# Altium schematic sheet 08_FPGA_C.SchDoc
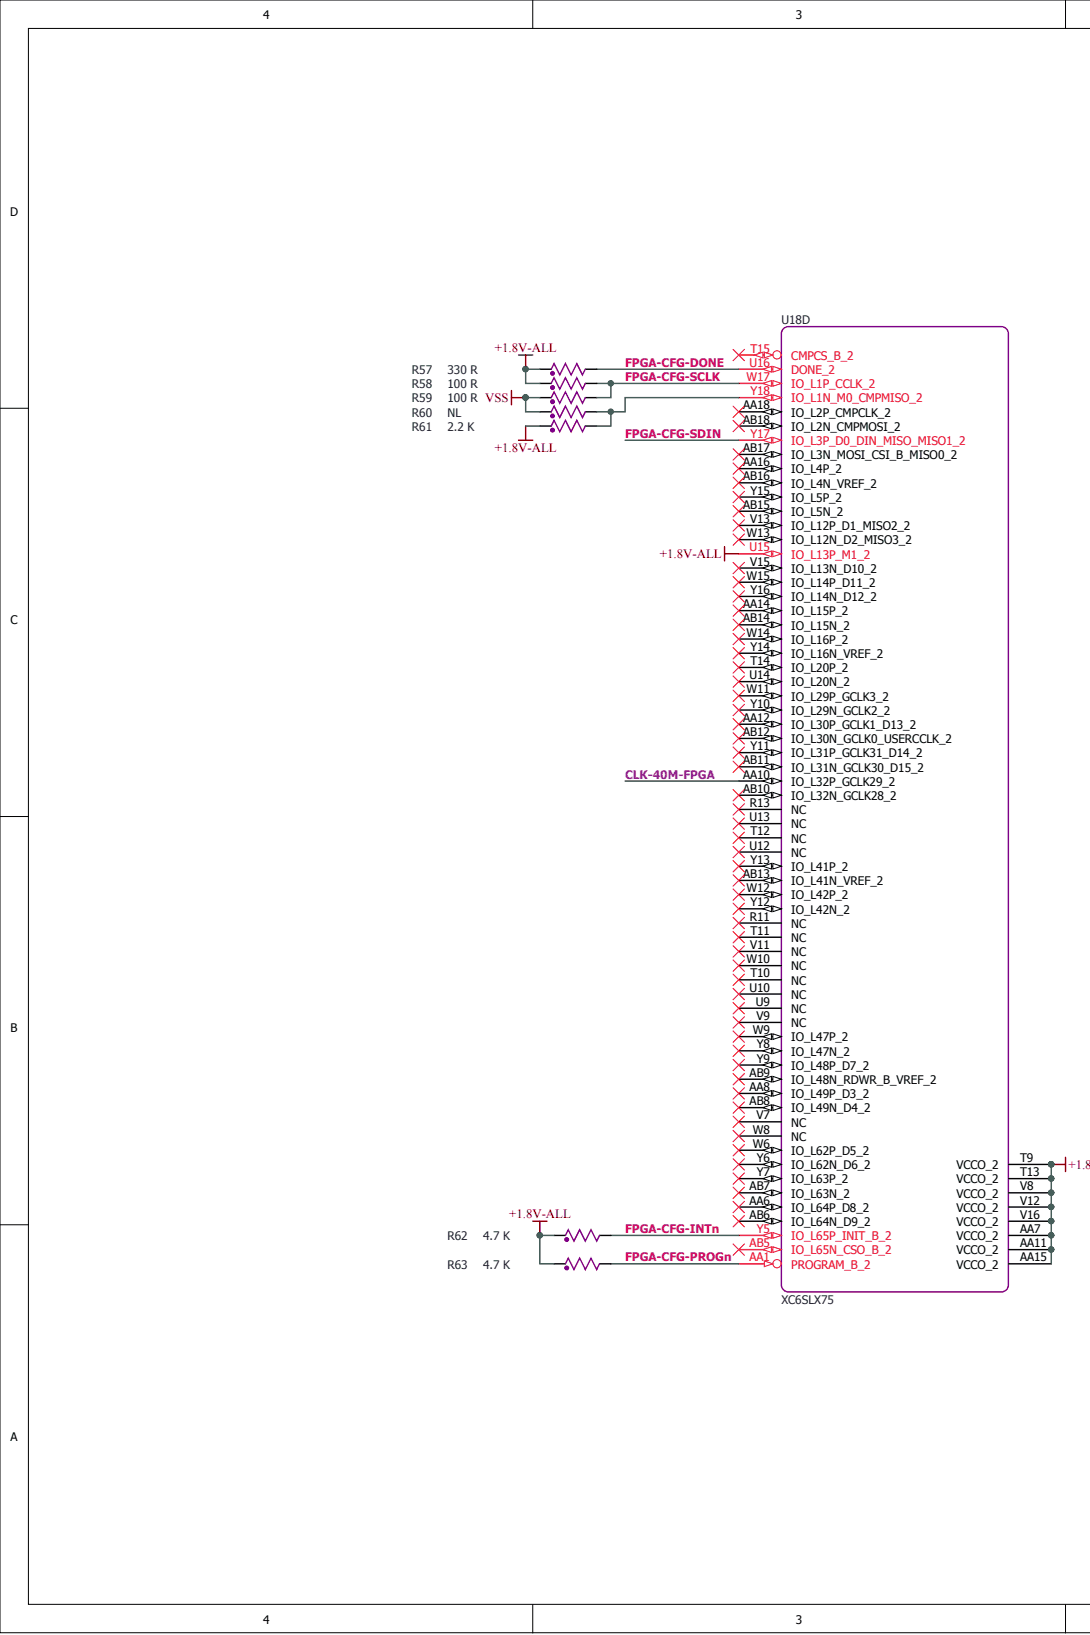
[diagram p0: 08_FPGA_C.SchDoc, left]
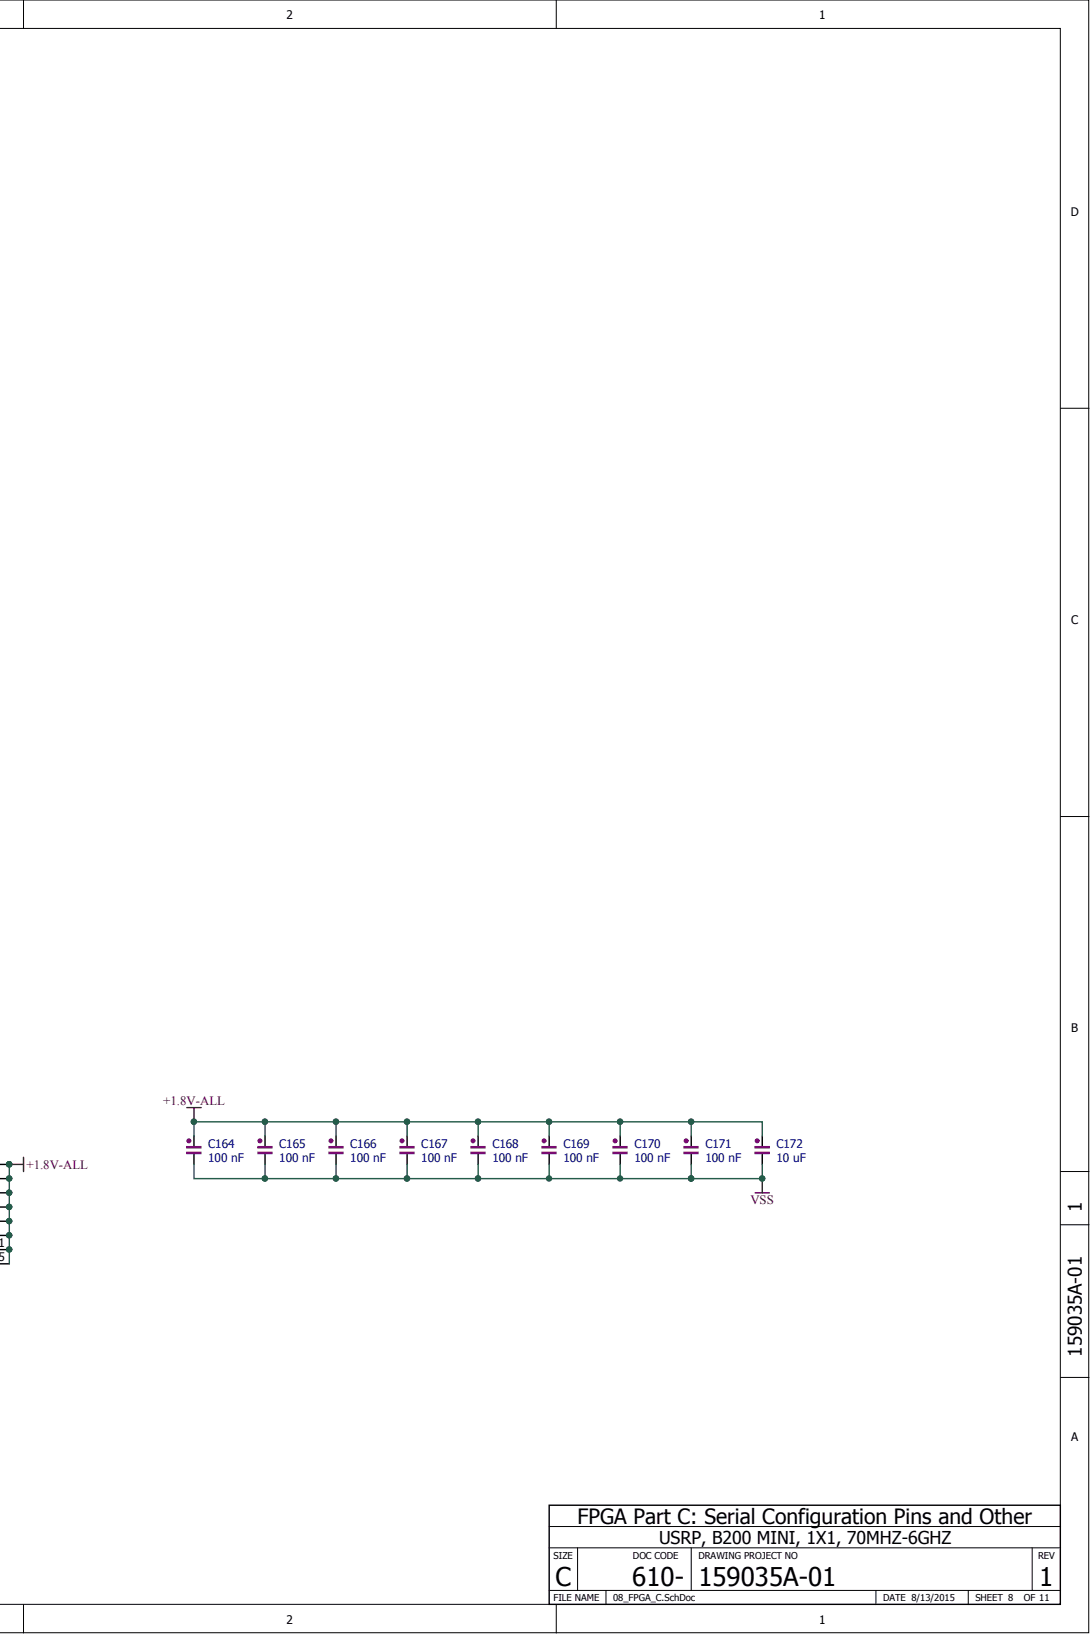
[diagram p1: 08_FPGA_C.SchDoc, right]

## Parts
C164  100 nF  [0201-CAP]
C165  100 nF  [0201-CAP]
C166  100 nF  [0201-CAP]
C167  100 nF  [0201-CAP]
C168  100 nF  [0201-CAP]
C169  100 nF  [0201-CAP]
C170  100 nF  [0201-CAP]
C171  100 nF  [0201-CAP]
C172  10 uF  [0402-CAP]
R57  330 R  [0201-RES]
R58  100 R  [0201-RES]
R59  100 R  [0201-RES]
R60  NL  [0201-RES-NL]
R61  2.2 K  [0201-RES]
R62  4.7 K  [0201-RES]
R63  4.7 K  [0201-RES]
U18  XC6SLX75  [CS(G)484-XC6SLX75]  (part D)

## Nets
+1.8V-ALL: C164.2 C165.2 C166.2 C167.2 C168.2 C169.2 C170.2 C171.2 C172.2 R57.2 R58.2 R61.2 R62.2 R63.2 U18.AA7(VCCO_2) U18.AA11(VCCO_2) U18.AA15(VCCO_2) U18.T9(VCCO_2) U18.T13(VCCO_2) U18.U15(IO_L13P_M1_2) U18.V8(VCCO_2) U18.V12(VCCO_2) U18.V16(VCCO_2)
VSS: C164.1 C165.1 C166.1 C167.1 C168.1 C169.1 C170.1 C171.1 C172.1 R59.2 R60.2
CLK-40M-FPGA: U18.AA10(IO_L32P_GCLK29_2)
FPGA-CFG-DONE: R57.1 U18.U16(DONE_2)
FPGA-CFG-INTN: R62.1 U18.Y5(IO_L65P_INIT_B_2)
FPGA-CFG-PROGN: R63.1 U18.AA1(PROGRAM_B_2)
FPGA-CFG-SCLK: R58.1 R59.1 U18.W17(IO_L1P_CCLK_2)
FPGA-CFG-SDIN: U18.Y17(IO_L3P_D0_DIN_MISO_MISO1_2)
NetR60_1: R60.1 R61.1 U18.Y18(IO_L1N_M0_CMPMISO_2)

Not connected: U18 AA6(IO_L64P_D8_2) AA8(IO_L49P_D3_2) AA12(IO_L30P_GCLK1_D13_2) AA14(IO_L15P_2) AA16(IO_L4P_2) AA18(IO_L2P_CMPCLK_2) AB5(IO_L65N_CSO_B_2) AB6(IO_L64N_D9_2) AB7(IO_L63N_2) AB8(IO_L49N_D4_2) AB9(IO_L48N_RDWR_B_VREF_2) AB10(IO_L32N_GCLK28_2) AB11(IO_L31N_GCLK30_D15_2) AB12(IO_L30N_GCLK0_USERCCLK_2) AB13(IO_L41N_VREF_2) AB14(IO_L15N_2) AB15(IO_L5N_2) AB16(IO_L4N_VREF_2) AB17(IO_L3N_MOSI_CSI_B_MISO0_2) AB18(IO_L2N_CMPMOSI_2) R11(NC) R13(NC) T10(NC) T11(NC) T12(NC) T14(IO_L20P_2) T15(CMPCS_B_2) U9(NC) U10(NC) U12(NC) U13(NC) U14(IO_L20N_2) V7(NC) V9(NC) V11(NC) V13(IO_L12P_D1_MISO2_2) V15(IO_L13N_D10_2) W6(IO_L62P_D5_2) W8(NC) W9(IO_L47P_2) W10(NC) W11(IO_L29P_GCLK3_2) W12(IO_L42P_2) W13(IO_L12N_D2_MISO3_2) W14(IO_L16P_2) W15(IO_L14P_D11_2) Y6(IO_L62N_D6_2) Y7(IO_L63P_2) Y8(IO_L47N_2) Y9(IO_L48P_D7_2) Y10(IO_L29N_GCLK2_2) Y11(IO_L31P_GCLK31_D14_2) Y12(IO_L42N_2) Y13(IO_L41P_2) Y14(IO_L16N_VREF_2) Y15(IO_L5P_2) Y16(IO_L14N_D12_2)

## Library data of the parts
C164-C171 = CAP: CAP,SM,0.1UF,16V,10%,CER,X5R,0201,H=.014IN; PartNumber: GRM033R61C104KE14D; Designer: shyul; PCBMarker: Capacitor; PinCountHole: 0; PinCountSMD: 2; SerialNumber: 717062-01; Vendor: MURATA; Component Kind: Standard; Value: 100 nF; Version: 1.0; VersionDate: 04-27-2011; Library Name: Basc_1_Passive.IntLib; Publisher: Cowin
C172 = CAP: CAP, 10uF, X5R, 6.3V, ±20%, 0402, PbF; PartNumber: C0402X5R6R3-106MNP; Designer: shyul; PCBMarker: Capacitor; PinCountHole: 0; PinCountSMD: 2; Vendor: VENKEL; Component Kind: Standard; SerialNumber: 0; Value: 10 uF; Version: 1.0; VersionDate: 04-27-2011; Library Name: Basc_1_Passive.IntLib; Publisher: Cowin
R57 = RES: RES,SM,330OHM,1/20W,5%,THICK FILM,0201,H=.012IN; PartNumber: ERJ-1GEJ331C; Designer: shyul; PCBMarker: Resistor; PinCountHole: 0; PinCountSMD: 2; SerialNumber: 612236-01; Vendor: PANASONIC; Component Kind: Standard; Value: 330 R; Version: 1.0; VersionDate: 04-27-2011; Library Name: Basc_1_Passive.IntLib; Publisher: Cowin
R58 R59 = RES: RES, 100R, ±5%, 1/20W, 0201, PbF; PartNumber: CR0201-20W-101JT; Designer: shyul; PCBMarker: Resistor; PinCountHole: 0; PinCountSMD: 2; Vendor: VENKEL; Component Kind: Standard; SerialNumber: 0; Value: 100 R; Version: 1.0; VersionDate: 04-27-2011; Library Name: Basc_1_Passive.IntLib; Publisher: Cowin
R60 = RES: NO LOAD; PartNumber: (N/A); Designer: shyul; PCBMarker: Resistor; PinCountHole: 0; PinCountSMD: 2; Component Kind: Standard; SerialNumber: 0; Value: NL; Vendor: (N/A); Version: 1.0; VersionDate: 04-27-2011; Library Name: Basc_1_Passive.IntLib; Publisher: Cowin
R61 = RES: RES, 2.2K, ±5%, 1/20W, 0201, PbF; PartNumber: CR0201-20W-222JT; Designer: shyul; PCBMarker: Resistor; PinCountHole: 0; PinCountSMD: 2; Vendor: VENKEL; Component Kind: Standard; SerialNumber: 0; Value: 2.2 K; Version: 1.0; VersionDate: 04-27-2011; Library Name: Basc_1_Passive.IntLib; Publisher: Cowin
R62 R63 = RES: RES, 4.7K, ±5%, 1/20W, 0201, PbF; PartNumber: CR0201-20W-472JT; Designer: shyul; PCBMarker: Resistor; PinCountHole: 0; PinCountSMD: 2; Vendor: VENKEL; Component Kind: Standard; SerialNumber: 0; Value: 4.7 K; Version: 1.0; VersionDate: 04-27-2011; Library Name: Basc_1_Passive.IntLib; Publisher: Cowin
U18 = XC6SLX75: Xilinx Spartan-6 FPGA; Designer: shyul; PinCountSMD: 484; SerialNumber: 0; Component Kind: Standard; PartNumber: XC6SLX75; Value: XC6SLX75; Vendor: Xilinx; Version: 1.0; VersionDate: 09-18-2014; Library Name: Argon.SchLib; Publisher: Shyu Lee
